# Altium schematic sheet power_supply.SchDoc
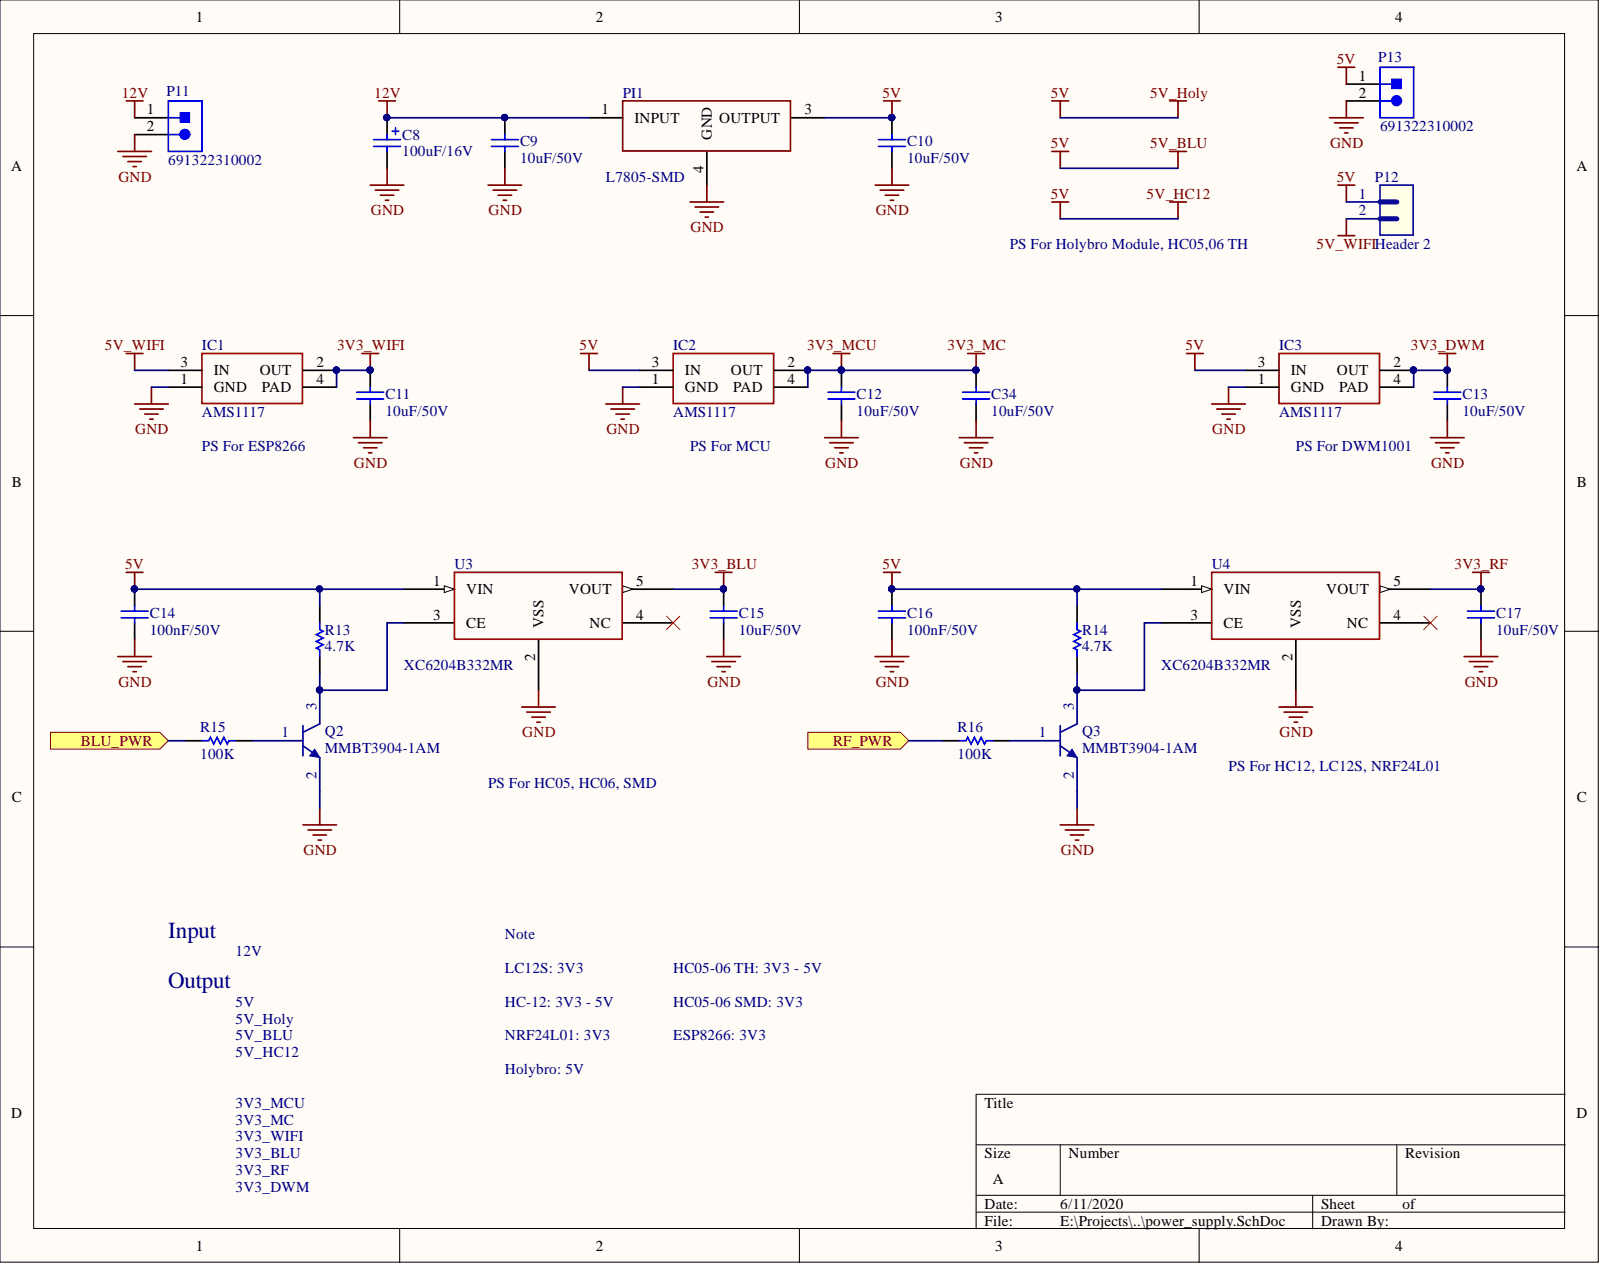
[diagram p0: power_supply.SchDoc]

## Parts
C8  value 100uF/16V  [CAP-SM-AL-SIZE-D-D6L6]  (hidden comment: Cap-Al-100uF-16V-D6L5)
C9  value 10uF/50V  [CAP-SM-0805]  (hidden comment: Cap-10uF-50V-0805)
C10  value 10uF/50V  [CAP-SM-0805]  (hidden comment: Cap-10uF-50V-0805)
C11  value 10uF/50V  [CAP-SM-0805]  (hidden comment: Cap-10uF-50V-0805)
C12  value 10uF/50V  [CAP-SM-0805]  (hidden comment: Cap-10uF-50V-0805)
C13  value 10uF/50V  [CAP-SM-0805]  (hidden comment: Cap-10uF-50V-0805)
C14  value 100nF/50V  [CAP-SM-0805]  (hidden comment: Cap-100nF-50V-0805)
C15  value 10uF/50V  [CAP-SM-0805]  (hidden comment: Cap-10uF-50V-0805)
C16  value 100nF/50V  [CAP-SM-0805]  (hidden comment: Cap-100nF-50V-0805)
C17  value 10uF/50V  [CAP-SM-0805]  (hidden comment: Cap-10uF-50V-0805)
C34  value 10uF/50V  [CAP-SM-0805]  (hidden comment: Cap-10uF-50V-0805)
IC1  AMS1117  [SOT223]
IC2  AMS1117  [SOT223]
IC3  AMS1117  [SOT223]
P11  691322310002  [691322310002]
P12  Header 2  [JP2]
P13  691322310002  [691322310002]
PI1  L7805-SMD  [TO254P1435X464-4N]
Q2  MMBT3904-1AM  [SOT23_NEX]
Q3  MMBT3904-1AM  [SOT23_NEX]
R13  value 4.7K  [RES-SM-0805]  (hidden comment: Res-4.7K-0805)
R14  value 4.7K  [RES-SM-0805]  (hidden comment: Res-4.7K-0805)
R15  value 100K  [RES-SM-0805]  (hidden comment: Res-100K-0805)
R16  value 100K  [RES-SM-0805]  (hidden comment: Res-100K-0805)
U3  XC6204B332MR  [XC6204/05_SOT-00]
U4  XC6204B332MR  [XC6204/05_SOT-00]

## Ports
BLU_PWR, RF_PWR

## Nets
3V3_BLU: C15.2 U3.5(VOUT)
3V3_DWM: C13.2 IC3.2(OUT) IC3.4(PAD)
3V3_MC: C12.2 C34.2 IC2.2(OUT) IC2.4(PAD)
3V3_RF: C17.2 U4.5(VOUT)
3V3_WIFI: C11.2 IC1.2(OUT) IC1.4(PAD)
5V: C10.2 C14.2 C16.2 IC2.3(IN) IC3.3(IN) P12.1 P13.1 PI1.3 R13.2 R14.2 U3.1(VIN) U4.1(VIN)
5V_WIFI: IC1.3(IN) P12.2
12V: C8.1 C9.2 P11.1 PI1.1
GND: C8.2 C9.1 C10.1 C11.1 C12.1 C13.1 C14.1 C15.1 C16.1 C17.1 C34.1 IC1.1(GND) IC2.1(GND) IC3.1(GND) P11.2 P13.2 PI1.4 Q2.2 Q3.2 U3.2(VSS) U4.2(VSS)
BLU_PWR: R15.1 ; port BLU_PWR
RF_PWR: R16.1 ; port RF_PWR
NetQ2_1: Q2.1 R15.2
NetQ2_3: Q2.3 R13.1 U3.3(CE)
NetQ3_1: Q3.1 R16.2
NetQ3_3: Q3.3 R14.1 U4.3(CE)

Not connected: U3 4(NC); U4 4(NC)

## Library data of the parts
C8 = Cap-Al-100uF-16V-D6L5: CAP ALUM 100UF 20% 16V SMD; Manufacturer: Nichicon; Manufacturer Part Number: UWX1C101MCL1GB; Component Kind: Standard; Library Name: Capacitor.SchLib; Local Supplier: thegioiic; Local Supplier Link: https://thegioiic.com/products/tu-nhom-smd-100uf-16v-d6-3l5-4; Supplier 1: Digikey; Supplier 1 Link: https://www.digikey.com/product-detail/en/nichicon/UWX1C101MCL1GB/493-2105-1-ND/590080; Supplier 1 Part Number: 493-2105-1-ND
C9-C13 C15 C17 C34 = Cap-10uF-50V-0805: CAP CER 10UF 50V X5R 0805; Manufacturer: Yageo; Manufacturer Part Number: CC0805KKX5R9BB106; Component Kind: Standard; Library Name: Capacitor.SchLib; Local Supplier: thegioiic; Local Supplier Link: https://thegioiic.com/products/tu-10uf-50v-0805; Supplier 1: Digikey; Supplier 1 Link: https://www.digikey.com/product-detail/en/yageo/CC0805KKX5R9BB106/311-3499-2-ND/7164383; Supplier 1 Part Number: 311-3499-2-ND
C14 C16 = Cap-100nF-50V-0805: CAP CER 0.1UF 50V Y5V 0805; Manufacturer: Samsung Electro-Mechanics; Manufacturer Part Number: CL21F104ZBCNNNC; Component Kind: Standard; Library Name: Capacitor.SchLib; Local Supplier: thegioiic; Local Supplier Link: https://thegioiic.com/products/tu-100nf-0-1uf-50v-0805; Supplier 1: Digikey; Supplier 1 Link: https://www.digikey.com/product-detail/en/samsung-electro-mechanics/CL21F104ZBCNNNC/1276-1007-1-ND/3889093; Supplier 1 Part Number: 1276-1007-1-ND
IC1-IC3 = AMS1117: Available in 1.8V, 2.5V, 2.85V, 3.3V, 5V, and  Adjustable Versions; Value: LM317; Component Kind: Standard; Library Name: PI.SchLib
P11 P13 = 691322310002: WR-TBL Serie 322 Horizontal PCB Header, Pluggable, pitch 3.81mm, 2p; Compliance: RoHS; Modularity: No; Pin Number: 2; Rated Current [UL]: 10A; Working Voltage [UL]: 300VAC; Application: Pluggable; Component Kind: Standard; ComponentLink1Description: Manufacturer; ComponentLink1URL: http://www.we-online.de; ComponentLink2Description: Datasheet; ComponentLink2URL: https://katalog.we-online.de/em/datasheet/691322310002.pdf; Library Name: 691322110004_Altium_WR-TBL_rev19a.IntLib; Mounting Technology: THT; Operating Temperature: -40 to +105degC; Packaging: Box; Part Number: 691322310002; PCB/Cable/Panel: PCB; Pitch: 3.81mm; Published Date: October 2019; Type: Horizontal; Publisher: Wurth Elektronik
P12 = Header 2: Header, 2-Pin; Component Kind: Standard; LatestRevisionDate: 17-Jul-2002; LatestRevisionNote: Re-released for DXP Platform.; Library Name: Header-Connector.SchLib; Publisher: Altium Limited
R13 R14 = Res-4.7K-0805: RES SMD 4.7K OHM 5% 1/8W 0805; Manufacturer: Yageo; Manufacturer Part Number: RC0805JR-074K7L; Component Kind: Standard; Library Name: Resistor.SchLib; Local Supplier: thegioiic; Local Supplier Link: https://thegioiic.com/products/dien-tro-4-7k-ohm-0805-5; Supplier 1: Digikey; Supplier 1 Link: https://www.digikey.com/product-detail/en/yageo/RC0805JR-074K7L/311-4.7KARCT-ND/731274; Supplier 1 Part Number: 311-4.7KARCT-ND
R15 R16 = Res-100K-0805: RES 0 OHM JUMPER 0805; Manufacturer: Vishay Dale; Manufacturer Part Number: WSL080500000ZEA9; Component Kind: Standard; Library Name: Resistor.SchLib; Local Supplier: thegioiic; Local Supplier Link: https://thegioiic.com/products/dien-tro-0-ohm-0805-5; Supplier 1: Digikey; Supplier 1 Link: https://www.digikey.com/product-detail/en/vishay-dale/WSL080500000ZEA9/541-3928-1-ND/7423223; Supplier 1 Part Number: 541-3928-1-ND
U3 U4 = XC6204B332MR: Built_By: EMA_UL_Team; SourceLibrary: Torex_2016-07-05_1; Vendor: Torex Semiconductor; Component Kind: Standard; Library Name: PI.SchLib; Manufacturer_Part_Number: XC6204B332MR; RefDes: RefDes; Type: DEV; Copyright: Copyright (C) 2018 Accelerated Designs. All rights reserved
PI1 = L7805-SMD: Component Kind: Standard; Library Name: PIFWRobot.SchLib
Q2 Q3 = MMBT3904-1AM: Component Kind: Standard; Library Name: BJT.SchLib
